# Altium schematic sheet LeBot.SchDoc(LeBot)
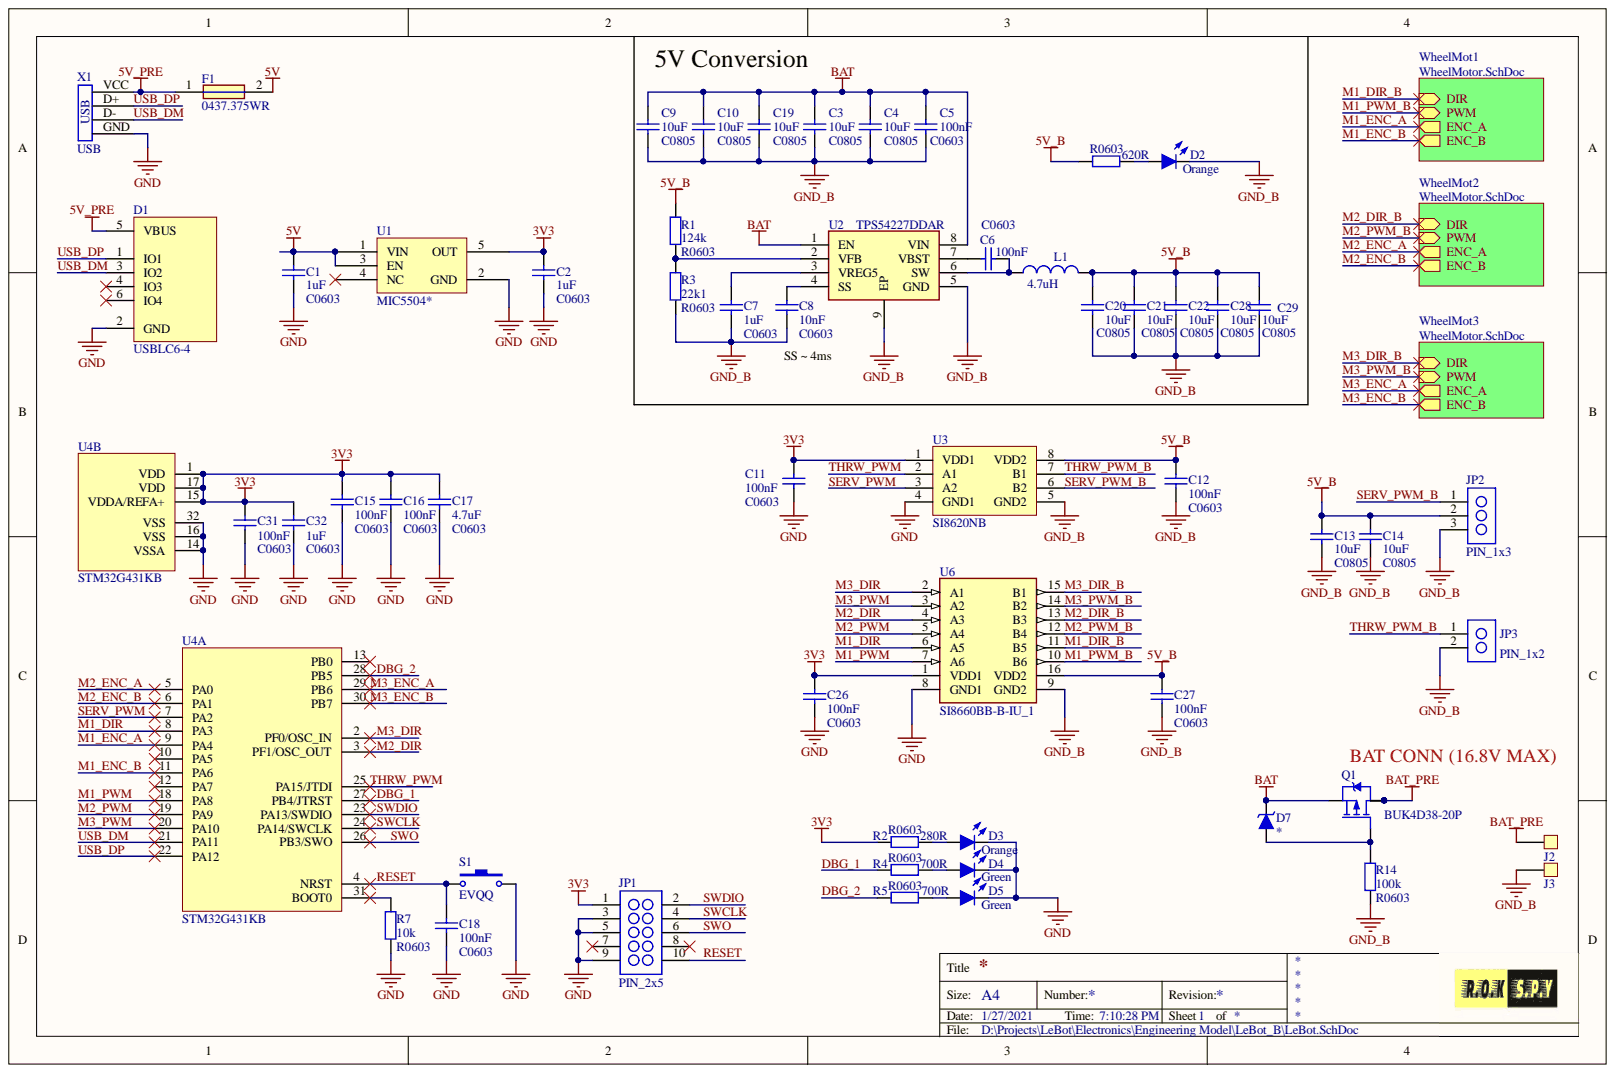
[diagram p0: LeBot.SchDoc]

## Parts
C1  Capacitance: 1uF  [C0603]  (hidden comment: 1uF*V)
C2  Capacitance: 1uF  [C0603]  (hidden comment: 1uF*V)
C3  Capacitance: 10uF  [C0805]  (hidden comment: 10uF*V)
C4  Capacitance: 10uF  [C0805]  (hidden comment: 10uF*V)
C5  Capacitance: 100nF  [C0603]  (hidden comment: 100nF*V)
C6  Capacitance: 100nF  [C0603]  (hidden comment: 100nF*V)
C7  Capacitance: 1uF  [C0603]  (hidden comment: 1uF*V)
C8  Capacitance: 10nF  [C0603]  (hidden comment: 10nF*V)
C9  Capacitance: 10uF  [C0805]  (hidden comment: 10uF*V)
C10  Capacitance: 10uF  [C0805]  (hidden comment: 10uF*V)
C11  Capacitance: 100nF  [C0603]  (hidden comment: 100nF*V)
C12  Capacitance: 100nF  [C0603]  (hidden comment: 100nF*V)
C13  Capacitance: 10uF  [C0805]  (hidden comment: 10uF*V)
C14  Capacitance: 10uF  [C0805]  (hidden comment: 10uF*V)
C15  Capacitance: 100nF  [C0603]  (hidden comment: 100nF*V)
C16  Capacitance: 100nF  [C0603]  (hidden comment: 100nF*V)
C17  Capacitance: 4.7uF  [C0603]  (hidden comment: 4.7uF*V)
C18  Capacitance: 100nF  [C0603]  (hidden comment: 100nF*V)
C19  Capacitance: 10uF  [C0805]  (hidden comment: 10uF*V)
C20  Capacitance: 10uF  [C0805]  (hidden comment: 10uF*V)
C21  Capacitance: 10uF  [C0805]  (hidden comment: 10uF*V)
C22  Capacitance: 10uF  [C0805]  (hidden comment: 10uF*V)
C26  Capacitance: 100nF  [C0603]  (hidden comment: 100nF*V)
C27  Capacitance: 100nF  [C0603]  (hidden comment: 100nF*V)
C28  Capacitance: 10uF  [C0805]  (hidden comment: 10uF*V)
C29  Capacitance: 10uF  [C0805]  (hidden comment: 10uF*V)
C31  Capacitance: 100nF  [C0603]  (hidden comment: 100nF*V)
C32  Capacitance: 1uF  [C0603]  (hidden comment: 1uF*V)
D1  USBLC6-4  [SOT-236]
D2  Colour: Orange  [LED_0805]
D3  Colour: Orange  [LED_0805]
D4  Colour: Green  [LED_0805]
D5  Colour: Green  [LED_0805]
D7  (no value)  [SOT23-Zener]
F1  0437.375WR  [FUSC3216X86N]
J2  Connection_pad  [Wire_Pad]  (value not drawn)
J3  Connection_pad  [Wire_Pad]  (value not drawn)
JP1  PIN_2x5  [1.27_2X5H]
JP2  PIN_1x3  [1.27_1X3H]
JP3  PIN_1x2  [1.27_1X2H]
L1  Inductance: 4.7uH  [L73]  (hidden comment: Inductor)
Q1  BUK4D38-20P  [PMPB12EPX]
R1  124k  [R0603]
R2  280R  [R0603]
R3  22k1  [R0603]
R4  700R  [R0603]
R5  700R  [R0603]
R7  10k  [R0603]
R8  620R  [R0603]
R14  100k  [R0603]
S1  EVQQ  [EVQQ]
U1  MIC5504*  [SOT-235]
U2  TPS54227DDAR  [SOIC127P600X175-8N]
U3  SI8620NB  [SOIC127P600X175-8N]
U4  STM32G431KB  [QFP80P900X900X160-32N]  (parts A,B)
U6  SI8660BB-B-IU_1  [SOP64P600X175-16N]
X1  USB  [USB MicroB Type 2.0]

## Nets
3V3: C2.2 C11.2 C15.2 C16.2 C17.2 C26.2 C31.2 C32.2 JP1.1 R2.2 U1.5(OUT) U3.1(VDD1) U4.1(VDD) U4.15(VDDA/REFA+) U4.17(VDD) U6.1(VDD1)
5V: C1.2 F1.2 U1.1(VIN) U1.3(EN)
5V_B: C12.2 C13.2 C14.2 C20.2 C21.2 C22.2 C27.2 C28.2 C29.2 JP2.2 L1.2 R1.2 R8.2 U3.8(VDD2) U6.16(VDD2)
5V_PRE: D1.5(VBUS) F1.1 X1.VCC
BAT: C3.2 C4.2 C5.2 C9.2 C10.2 C19.2 D7.2 Q1.1 U2.1(EN) U2.8(VIN)
BAT_PRE: J2.1 Q1.3 Q1.D0 Q1.D1 Q1.D2
GND: C1.1 C2.1 C11.1 C15.1 C16.1 C17.1 C18.1 C26.1 C31.1 C32.1 D1.2(GND) D3.2 D4.2 D5.2 JP1.3 JP1.5 JP1.9 R7.1 S1.2 U1.2(GND) U3.4(GND1) U4.14(VSSA) U4.16(VSS) U4.32(VSS) U6.8(GND1) X1.GND
GND_B: C3.1 C4.1 C5.1 C7.1 C8.1 C9.1 C10.1 C12.1 C13.1 C14.1 C19.1 C20.1 C21.1 C22.1 C27.1 C28.1 C29.1 D2.2 J3.1 JP2.3 JP3.2 R3.1 R14.2 U2.5(GND) U2.9(EP) U3.5(GND2) U6.9(GND2)
DBG_1: R4.2 U4.27(PB4/JTRST)
DBG_2: R5.2 U4.28(PB5)
M1_DIR: U4.8(PA3) U6.6(A5)
M1_DIR_B: U6.11(B5)
M1_ENC_A: U4.9(PA4)
M1_ENC_B: U4.11(PA6)
M1_PWM: U4.18(PA8) U6.7(A6)
M1_PWM_B: U6.10(B6)
M2_DIR: U4.3(PF1/OSC_OUT) U6.4(A3)
M2_DIR_B: U6.13(B3)
M2_ENC_A: U4.5(PA0)
M2_ENC_B: U4.6(PA1)
M2_PWM: U4.19(PA9) U6.5(A4)
M2_PWM_B: U6.12(B4)
M3_DIR: U4.2(PF0/OSC_IN) U6.2(A1)
M3_DIR_B: U6.15(B1)
M3_ENC_A: U4.29(PB6)
M3_ENC_B: U4.30(PB7)
M3_PWM: U4.20(PA10) U6.3(A2)
M3_PWM_B: U6.14(B2)
RESET: C18.2 JP1.10 S1.1 U4.4(NRST)
SERV_PWM: U3.3(A2) U4.7(PA2)
SERV_PWM_B: JP2.1 U3.6(B2)
SWCLK: JP1.4 U4.24(PA14/SWCLK)
SWDIO: JP1.2 U4.23(PA13/SWDIO)
SWO: JP1.6 U4.26(PB3/SWO)
THRW_PWM: U3.2(A1) U4.25(PA15/JTDI)
THRW_PWM_B: JP3.1 U3.7(B1)
USB_DM: D1.3(IO2) U4.21(PA11) X1.D-
USB_DP: D1.1(IO1) U4.22(PA12) X1.D+
NetC6_1: C6.1 L1.1 U2.6(SW)
NetC6_2: C6.2 U2.7(VBST)
NetC7_2: C7.2 U2.3(VREG5)
NetC8_2: C8.2 U2.4(SS)
NetD1_4: D1.4(IO3)
NetD1_6: D1.6(IO4)
NetD2_1: D2.1 R8.1
NetD3_1: D3.1 R2.1
NetD4_1: D4.1 R4.1
NetD5_1: D5.1 R5.1
NetD7_1: D7.1 Q1.2 R14.1
NetJP1_7: JP1.7
NetJP1_8: JP1.8
NetR1_1: R1.1 R3.2 U2.2(VFB)
NetR7_2: R7.2 U4.31(BOOT0)
NetU1_4: U1.4(NC)
NetU4_10: U4.10(PA5)
NetU4_12: U4.12(PA7)
NetU4_13: U4.13(PB0)

## Library data of the parts
C1-C22 C26-C29 C31 C32 = Capacitor: Ceramic capacitor; Voltage rating: *V; Type: MLCC; ComponentLinknDescription: Datasheet; Library Name: SCH Passives.SchLib; Component Kind: Standard
  C1 C2 C5-C8 C11 C12 C15-C18 C26 C27 C31 C32: Note: C0603
  C3 C4 C9 C10 C13 C14 C19-C22 C28 C29: Note: C0805
D1 = USBLC6-4: USB ESD Protection; Manufacturer: ST Microelectronics; Space heritage: false; ComponentLinknDescription: Datasheet; Verified: false; Part number: USBLC6-4; Library Name: SCH Diodes.SchLib; Component Kind: Standard
D2 D3 = LED: Light Emitting Diode; Part number: MC011368; Space heritage: false; Forward Voltage: 2.1V; Forward Current: 20mA; ComponentLinknDescription: Datasheet; Power dissipation: false; Library Name: Diodes.SchLib; Farnell Order Nr: 3015159; Component Kind: Standard; Comment: ="PartNumber"
D4 D5 = LED: Light Emitting Diode; Part number: KP-2012LSGC; Space heritage: false; Forward Voltage: 1.9V; Forward Current: 2mA; ComponentLinknDescription: Datasheet; Power dissipation: false; Library Name: Diodes.SchLib; Farnell Order Nr: 2463991; Component Kind: Standard; Comment: ="PartNumber"
D7 = Zener Diode: Library Name: Diodes.SchLib; Component Kind: Standard
F1 = 0437.375WR: Fuse; Manufacturer_Name: LITTELFUSE; Manufacturer_Part_Number: 0437.375WR; Height: 0.864mm; Datasheet Link: https://ms.componentsearchengine.com/Datasheets/2/0437.375WR.pdf; Component Kind: Standard
L1 = Inductor: Self resonant frequency: *Hz; Saturation current: *A; Note: 4.7uHL73; DC resistance: *R; ComponentLinknDescription: Datasheet; RMS current: *A; Library Name: SCH Passives.SchLib; Description: Inductor; Component Kind: Standard
Q1 = P-Channel MOSFET: rDSon: 30mOhm; GS breakdown Volt: 12V/-12V; DS Breakdown Volt: -20V; Drain Current: -18A; PartNumber: BUK4D38-20P; Mouser Order Nr: 771-BUK4D38-20PX; Library Name: Transistors.SchLib; Farnell Order Nr: 3526152; Description: P-Channel MOSFET; Datasheet: https://eu.mouser.com/datasheet/2/916/BUK4D38-20P-1880069.pdf; Component Kind: Standard
R1-R5 R7 R8 R14 = Resistor: Voltage rating: *V; Temp coefficient: *ppm; Precision: *%; Power rating: *mW; ComponentLinknDescription: Datasheet; Note: R0603; Library Name: SCH Passives.SchLib; Description: Resistor; Component Kind: Standard
  R1: Resistance: 124k
  R2: Resistance: 280R
  R3: Resistance: 22k1
  R4 R5: Resistance: 700R
  R7: Resistance: 10k
  R8: Resistance: 620R
  R14: Resistance: 100k
S1 = EVQQ: Switch; Manufacturer: Panasonic; Space heritage: false; ComponentLinknDescription: Datasheet; Verified: false; Part number: EVQQ; Library Name: SCH Switch.SchLib; Component Kind: Standard; Drawn by: Erik Ilbis
U1 = MIC5504: Low-dropout voltage regulator; Manufacturer: Microchip/Micrel; Space heritage: false; Input Voltage: 2V5 to 5V5; Current Rating: 300 mA; Accuracy: 2%; Verified: false; Part number: MIC5504; Library Name: SCH Voltage regulators.SchLib; ComponentLink1URL: https://www.mouser.ee/datasheet/2/268/MIC550X-1082389.pdf; ComponentLink1Description: Datasheet; Component Kind: Standard; Drawn by: Roberts Oskars Komarovskis
U2 = TPS54227DDAR: 4.5-V to 18-V Input, 2-A SynchronousStep-DownConverter; Manufacturer_Name: Texas Instruments; PartNumber: TPS54227DDAR; Manufacturer_Part_Number: TPS54227DDAR; Library Name: Voltage Regulators.SchLib; Height: 1.7mm; Farnell Order Nr: 3007320; Datasheet Link: http://www.ti.com/lit/gpn/tps54227; Component Kind: Standard
U3 = SI8620BB-B-IS: Digital Isolator, 2 Channel, 8 ns, 2.5 V, 5.5 V, NSOIC, 8 Pins; PartNumber: SI86; Manufacturer: Silicon Labs; Str1: NB; PackageType: 20; Library Name: Misc.SchLib; Datasheet: http://www.farnell.com/datasheets/2244974.pdf?_ga=2.133957773.2069242502.1604233078-1290644116.1596735146&_gac=1.216125090.1601500870.EAIaIQobChMI2YybtueR7AIVis_tCh0ENQp0EAAYASAAEgKKifD_BwE; ComponentLink1URL: http://www.farnell.com/datasheets/2244974.pdf?_ga=2.133957773.2069242502.1604233078-1290644116.1596735146&_gac=1.216125090.1601500870.EAIaIQobChMI2YybtueR7AIVis_tCh0ENQp0EAAYASAAEgKKifD_BwE; ComponentLink1Description: Datasheet; Component Kind: Standard; Drawn By: Roberts Oskars Komarovskis
U4 = STM32G431KB: ARM MCU, STM32 Family STM32G4 Series Microcontrollers, ARM Cortex-M4F, 32bit, 170 MHz, 64 KB, 32 KB; Manufacturer: STMicroelectronics; Library Name: MCU.SchLib; Farnell Order Nr: 3515807; Datasheet: https://eu.mouser.com/datasheet/2/389/stm32g431c6-1600866.pdf; ComponentLink1URL: 3515807; ComponentLink1Description: Datasheet; Component Kind: Standard; Drawn By: Roberts Oskars Komarovskis
U6 = SI8660: Low Power Six-Channel Digital Isolator; Manufacturer_Part_Number: SI8661BB-B-IU; Manufacturer_Name: Silicon Labs; PartNumber: SI8660BB-B-IU_1; Mouser2 Price/Stock: https://www.mouser.com/Search/Refine.aspx?Keyword=634-SI8661BB-B-IU; Mouser2 Part Number: 634-SI8661BB-B-IU; Library Name: Misc.SchLib; Height: 1.75mm; Farnell Order Nr: 2423337; Datasheet Link: https://componentsearchengine.com//SI8661BB-B-IU.pdf; ComponentLink1URL: https://4donline.ihs.com/images/VipMasterIC/IC/SILC/SILC-S-A0009375737/SILC-S-A0009375737-1.pdf?hkey=6D3A4C79FDBF58556ACFDE234799DDF0; ComponentLink1Description: Datasheet; Component Kind: Standard
J2 J3 = Connection_pad: Library Name: Connectors.SchLib; Component Kind: Standard
JP1 = PIN_2x5: Component Kind: Standard; Library Name: SCH Pinhead.SchLib; Drawn By: Erik Ilbis
JP2 = PIN_1x3: Component Kind: Standard; Library Name: SCH Pinhead.SchLib; Drawn By: Erik Ilbis
JP3 = PIN_1x2: Component Kind: Standard; Library Name: SCH Pinhead.SchLib; Drawn By: Erik Ilbis
X1 = USB: Library Name: SCH Connectors.SchLib; Component Kind: Standard
